# Altium schematic sheet 16MV1.SchDoc
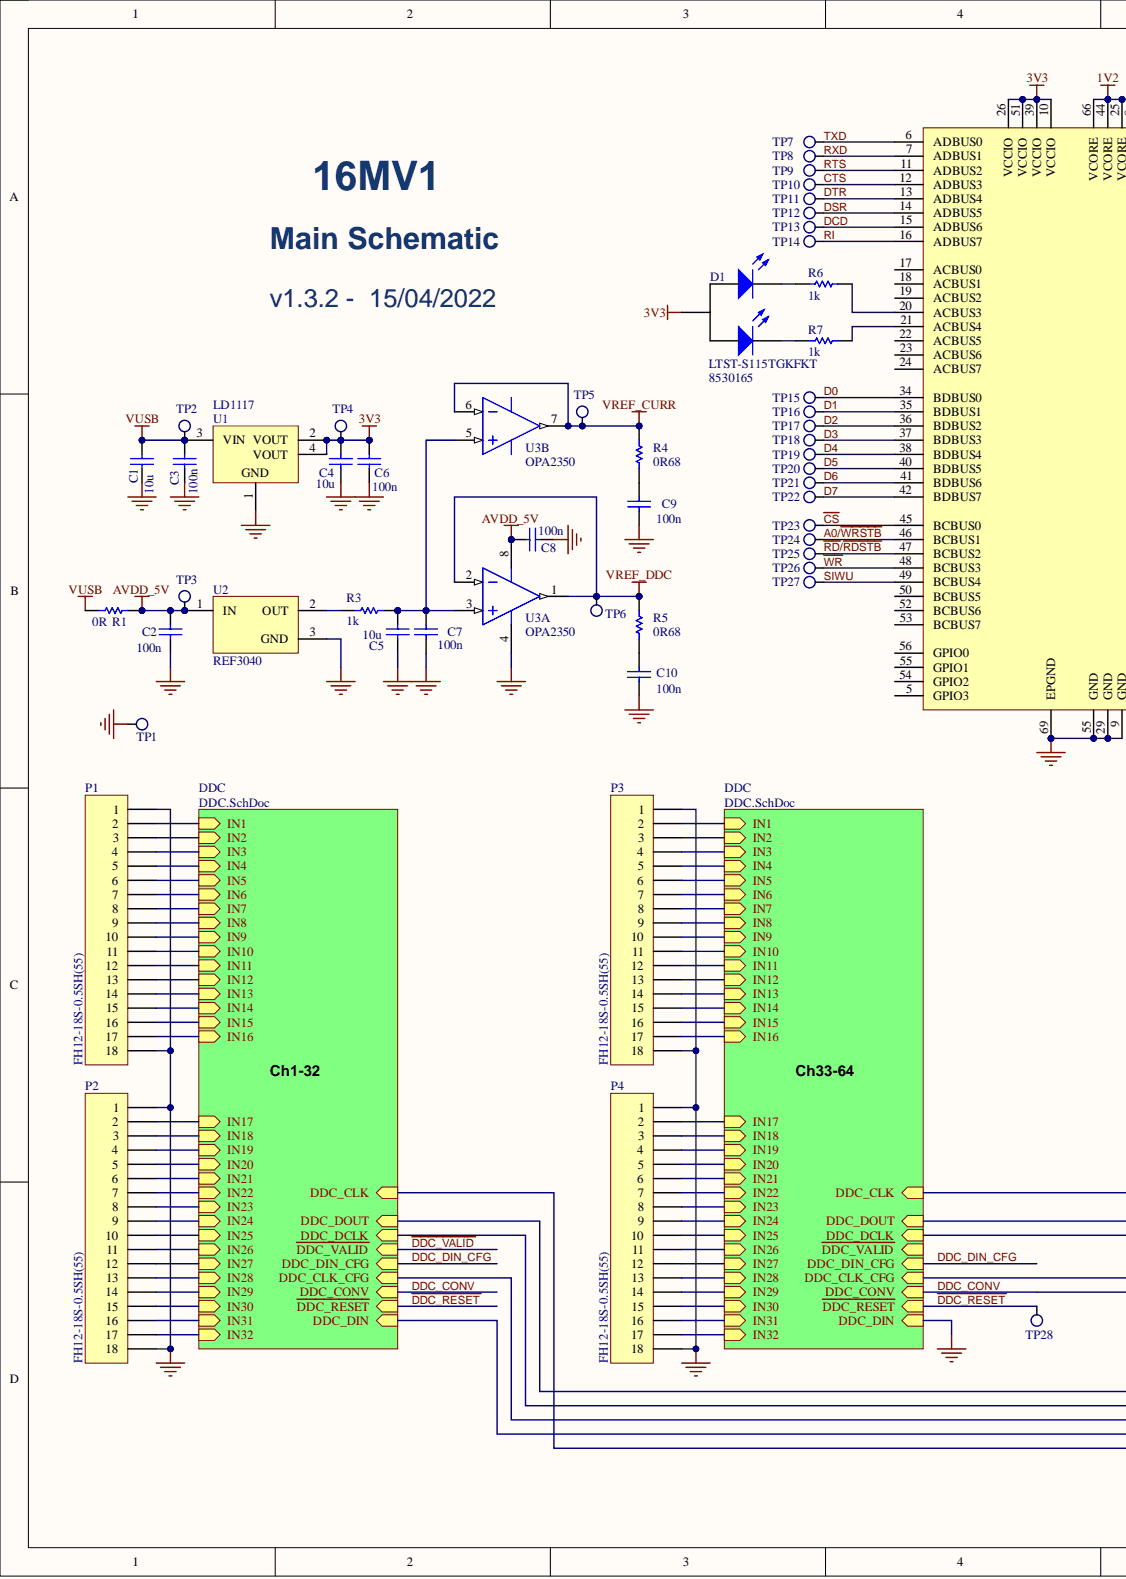
[diagram p0: 16MV1.SchDoc, left]
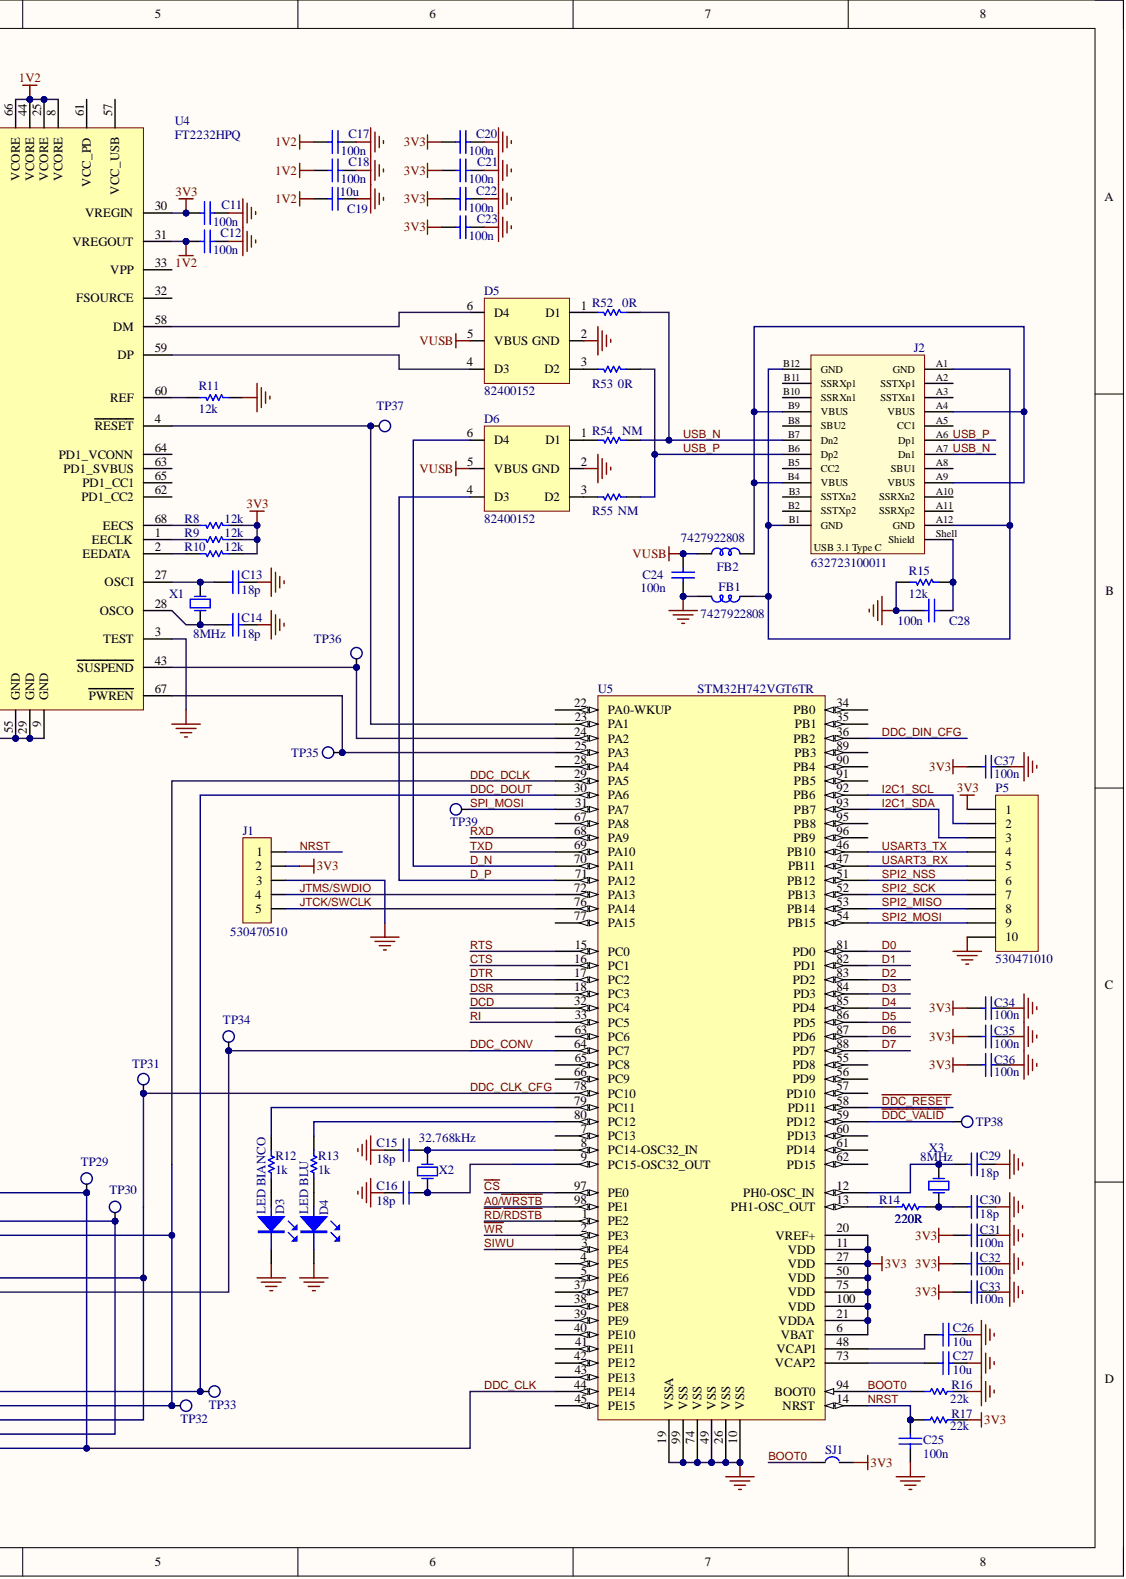
[diagram p1: 16MV1.SchDoc, right]

## Parts
C1  10u  [0603]
C2  100n  [0402]
C3  100n  [0402]
C4  10u  [0603]
C5  10u  [0603]
C6  100n  [0402]
C7  100n  [0402]
C8  100n  [0402]
C9  100n  [0402]
C10  100n  [0402]
C11  100n  [0402]
C12  100n  [0402]
C13  18p  [0402]
C14  18p  [0402]
C15  18p  [0402]
C16  18p  [0402]
C17  100n  [0402]
C18  100n  [0402]
C19  10u  [0603]
C20  100n  [0402]
C21  100n  [0402]
C22  100n  [0402]
C23  100n  [0402]
C24  100n  [0402]
C25  100n  [0402]
C26  10u  [0603]
C27  10u  [0603]
C28  100n  [0402]
C29  18p  [0402]
C30  18p  [0402]
C31  100n  [0402]
C32  100n  [0402]
C33  100n  [0402]
C34  100n  [0402]
C35  100n  [0402]
C36  100n  [0402]
C37  100n  [0402]
D1  LTST-S115TGKFKT  Supplier Part Number 1: 8530165  [LED BICOLOR R/A SMD]
D3  LED BIANCO  [LED_SMD]
D4  LED BLU  [LED_SMD]
D5  82400152  [SOT-666]
D6  82400152  [SOT-666]
FB1  7427922808  [0603]
FB2  7427922808  [0603]
J1  530470510  [MOLEX_5_V]
J2  632723100011  Interface Type: Type C  Sub-Family: USB 3.1  [632723100011]
P1  FH12-18S-0.5SH(55)  [FH12-18S-0.5SH(55)]
P2  FH12-18S-0.5SH(55)  [FH12-18S-0.5SH(55)]
P3  FH12-18S-0.5SH(55)  [FH12-18S-0.5SH(55)]
P4  FH12-18S-0.5SH(55)  [FH12-18S-0.5SH(55)]
P5  530471010  [HDR1X10]
R1  0R  [0402]
R3  1k  [0402]
R4  0R68  [0402]
R5  0R68  [0402]
R6  1k  [0402]
R7  1k  [0402]
R8  12k  [0402]
R9  12k  [0402]
R10  12k  [0402]
R11  12k  [0402]
R12  1k  [0402]
R13  1k  [0402]
R14  220R  [0402]
R15  12k  [0402]
R16  22k  [0402]
R17  22k  [0402]
R52  0R  [0402]
R53  0R  [0402]
R54  NM  [0402]
R55  NM  [0402]
SJ1  SJ  [Solder_j]
TP1  TestPoint  [PIAZZOLA]  (value not drawn)
TP2  TestPoint  [PIAZZOLA]  (value not drawn)
TP3  TestPoint  [PIAZZOLA]  (value not drawn)
TP4  TestPoint  [PIAZZOLA]  (value not drawn)
TP5  TestPoint  [PIAZZOLA]  (value not drawn)
TP6  TestPoint  [PIAZZOLA]  (value not drawn)
TP7  TestPoint  [PIAZZOLA]  (value not drawn)
TP8  TestPoint  [PIAZZOLA]  (value not drawn)
TP9  TestPoint  [PIAZZOLA]  (value not drawn)
TP10  TestPoint  [PIAZZOLA]  (value not drawn)
TP11  TestPoint  [PIAZZOLA]  (value not drawn)
TP12  TestPoint  [PIAZZOLA]  (value not drawn)
TP13  TestPoint  [PIAZZOLA]  (value not drawn)
TP14  TestPoint  [PIAZZOLA]  (value not drawn)
TP15  TestPoint  [PIAZZOLA]  (value not drawn)
TP16  TestPoint  [PIAZZOLA]  (value not drawn)
TP17  TestPoint  [PIAZZOLA]  (value not drawn)
TP18  TestPoint  [PIAZZOLA]  (value not drawn)
TP19  TestPoint  [PIAZZOLA]  (value not drawn)
TP20  TestPoint  [PIAZZOLA]  (value not drawn)
TP21  TestPoint  [PIAZZOLA]  (value not drawn)
TP22  TestPoint  [PIAZZOLA]  (value not drawn)
TP23  TestPoint  [PIAZZOLA]  (value not drawn)
TP24  TestPoint  [PIAZZOLA]  (value not drawn)
TP25  TestPoint  [PIAZZOLA]  (value not drawn)
TP26  TestPoint  [PIAZZOLA]  (value not drawn)
TP27  TestPoint  [Piazzola]  (value not drawn)
TP28  TestPoint  [PIAZZOLA]  (value not drawn)
TP29  TestPoint  [PIAZZOLA]  (value not drawn)
TP30  TestPoint  [PIAZZOLA]  (value not drawn)
TP31  TestPoint  [PIAZZOLA]  (value not drawn)
TP32  TestPoint  [PIAZZOLA]  (value not drawn)
TP33  TestPoint  [PIAZZOLA]  (value not drawn)
TP34  TestPoint  [PIAZZOLA]  (value not drawn)
TP35  TestPoint  [PIAZZOLA]  (value not drawn)
TP36  TestPoint  [PIAZZOLA]  (value not drawn)
TP37  TestPoint  [PIAZZOLA]  (value not drawn)
TP38  TestPoint  [PIAZZOLA]  (value not drawn)
TP39  TestPoint  [PIAZZOLA]  (value not drawn)
U1  LD1117  [SOT223-4M]
U2  REF3040  [SOT23-3L]
U3  OPA2350  [MSOP8]  (parts A,B)
U4  FT2232HPQ  [QFN68]
U5  STM32H742VGT6TR  [QFP50P1600X1600X160-100N]
X1  8MHz  [XTAL_SMD3225]
X2  32.768kHz  [XTAL FC-13]
X3  8MHz  [XTAL_SMD3225]

## Nets
1V2: C12.1 C17.1 C18.1 C19.2 U4.8(VCORE) U4.25(VCORE) U4.31(VREGOUT) U4.44(VCORE) U4.66(VCORE)
3V3: C4.1 C6.1 C11.1 C20.1 C21.1 C22.1 C23.1 C31.1 C32.1 C33.1 C34.1 C35.1 C36.1 C37.1 D1.1 J1.2 P5.1 R8.2 R9.2 R10.2 R17.2 SJ1.1 TP4.1 U1.2(VOUT) U1.4(VOUT) U4.10(VCCIO) U4.26(VCCIO) U4.30(VREGIN) U4.39(VCCIO) U4.51(VCCIO) U5.6(VBAT) U5.11(VDD) U5.20(VREF+) U5.21(VDDA) U5.27(VDD) U5.50(VDD) U5.75(VDD) U5.100(VDD)
AVDD_5V: C2.1 C8.1 R1.1 TP3.1 U2.1 U3.8
GND: C1.2 C2.2 C3.2 C4.2 C5.2 C6.2 C7.2 C8.2 C9.2 C10.2 C11.2 C12.2 C13.1 C14.1 C15.2 C16.2 C17.2 C18.2 C19.1 C20.2 C21.2 C22.2 C23.2 C24.2 C25.2 C26.1 C27.1 C28.2 C29.1 C30.1 C31.2 C32.2 C33.2 C34.2 C35.2 C36.2 C37.2 D3.2 D4.2 D5.2(GND) D6.2(GND) FB1.2 J1.3 P1.1 P1.18 P2.1 P2.18 P3.1 P3.18 P4.1 P4.18 P5.10 R11.2 R15.1 R16.2 TP1.1 U1.1 U2.3 U3.4 U4.3(TEST) U4.9(GND) U4.29(GND) U4.55 U4.69(EPGND) U5.10(VSS) U5.19(VSSA) U5.26(VSS) U5.49(VSS) U5.74(VSS) U5.99(VSS)
VREF_CURR: R4.2 TP5.1 U3.6 U3.7
VREF_DDC: R5.2 TP6.1 U3.1 U3.2
VUSB: C1.1 C3.1 C24.1 D5.5(VBUS) D6.5(VBUS) FB2.1 R1.2 TP2.1 U1.3(VIN)
A0/~{WRSTB}: TP24.1 U4.46(BCBUS1) U5.98(PE1)
BOOT0: R16.1 SJ1.2 U5.94(BOOT0)
~{CS}: TP23.1 U4.45(BCBUS0) U5.97(PE0)
CTS: TP10.1 U4.12(ADBUS3) U5.16(PC1)
D0: TP15.1 U4.34(BDBUS0) U5.81(PD0)
D1: TP16.1 U4.35(BDBUS1) U5.82(PD1)
D2: TP17.1 U4.36(BDBUS2) U5.83(PD2)
D3: TP18.1 U4.37(BDBUS3) U5.84(PD3)
D4: TP19.1 U4.38(BDBUS4) U5.85(PD4)
D5: TP20.1 U4.40(BDBUS5) U5.86(PD5)
D6: TP21.1 U4.41(BDBUS6) U5.87(PD6)
D7: TP22.1 U4.42(BDBUS7) U5.88(PD7)
~{DDC_RESET}: TP28.1 U5.58(PD11)
~{DDC_VALID}: TP38.1 U5.59(PD12)
D_N: D6.6(D4) U5.70(PA11)
D_P: D6.4(D3) U5.71(PA12)
DCD: TP13.1 U4.15(ADBUS6) U5.32(PC4)
DDC_CLK: TP29.1 U5.44(PE14)
DDC_CLK_CFG: TP31.1 U5.78(PC10)
DDC_CONV: TP34.1 U5.64(PC7)
DDC_DCLK: TP32.1 U5.29(PA5)
DDC_DIN_CFG: U5.36(PB2)
DDC_DOUT: TP33.1 U5.30(PA6)
DSR: TP12.1 U4.14(ADBUS5) U5.18(PC3)
DTR: TP11.1 U4.13(ADBUS4) U5.17(PC2)
I2C1_SCL: P5.2 U5.92(PB6)
I2C1_SDA: P5.3 U5.93(PB7)
JTCK/SWCLK: J1.5 U5.76(PA14)
JTMS/SWDIO: J1.4 U5.72(PA13)
NRST: C25.1 J1.1 R17.1 U5.14(NRST)
~{RD}/~{RDSTB}: TP25.1 U4.47(BCBUS2) U5.1(PE2)
RI: TP14.1 U4.16(ADBUS7) U5.33(PC5)
RTS: TP9.1 U4.11(ADBUS2) U5.15(PC0)
RXD: TP8.1 U4.7(ADBUS1) U5.68(PA9)
SIWU: TP27.1 U4.49(BCBUS4) U5.3(PE4)
SPI2_MISO: P5.8 U5.53(PB14)
SPI2_MOSI: P5.9 U5.54(PB15)
SPI2_NSS: P5.6 U5.51(PB12)
SPI2_SCK: P5.7 U5.52(PB13)
SPI_MOSI: TP39.1 U5.31(PA7)
TXD: TP7.1 U4.6(ADBUS0) U5.69(PA10)
USART3_RX: P5.5 U5.47(PB11)
USART3_TX: P5.4 U5.46(PB10)
USB_N: J2.A7(Dn1) J2.B7(Dn2) R52.2 R54.2
USB_P: J2.A6(Dp1) J2.B6(Dp2) R53.2 R55.2
~{WR}: TP26.1 U4.48(BCBUS3) U5.2(PE3)
NetC5_1: C5.1 C7.1 R3.1 U3.3 U3.5
NetC9_1: C9.1 R4.1
NetC10_1: C10.1 R5.1
NetC13_2: C13.2 U4.27(OSCI) X1.3
NetC14_2: C14.2 U4.28(OSCO) X1.1
NetC15_1: C15.1 U5.8(PC14-OSC32_IN) X2.2
NetC16_1: C16.1 U5.9(PC15-OSC32_OUT) X2.1
NetC26_2: C26.2 U5.48(VCAP1)
NetC27_2: C27.2 U5.73(VCAP2)
NetC28_1: C28.1 J2.Shell(Shield) R15.2
NetC29_2: C29.2 U5.12(PH0-OSC_IN) X3.3
NetC30_2: C30.2 R14.2 X3.1
NetD1_2: D1.2 R7.1
NetD1_3: D1.3 R6.1
NetD3_1: D3.1 R12.1
NetD4_1: D4.1 R13.1
NetD5_1: D5.1(D1) R52.1
NetD5_3: D5.3(D2) R53.1
NetD5_4: D5.4(D3) U4.59(DP)
NetD5_6: D5.6(D4) U4.58(DM)
NetD6_1: D6.1(D1) R54.1
NetD6_3: D6.3(D2) R55.1
NetFB1_1: FB1.1 J2.A1(GND) J2.A12(GND) J2.B1(GND) J2.B12(GND)
NetFB2_2: FB2.2 J2.A4(VBUS) J2.A9(VBUS) J2.B4(VBUS) J2.B9(VBUS)
NetJ2_A2: J2.A2(SSTXp1)
NetJ2_A3: J2.A3(SSTXn1)
NetJ2_A5: J2.A5(CC1)
NetJ2_A8: J2.A8(SBU1)
NetJ2_A10: J2.A10(SSRXn2)
NetJ2_A11: J2.A11(SSRXp2)
NetJ2_B2: J2.B2(SSTXp2)
NetJ2_B3: J2.B3(SSTXn2)
NetJ2_B5: J2.B5(CC2)
NetJ2_B8: J2.B8(SBU2)
NetJ2_B10: J2.B10(SSRXn1)
NetJ2_B11: J2.B11(SSRXp1)
NetP1_2: P1.2
NetP1_3: P1.3
NetP1_4: P1.4
NetP1_5: P1.5
NetP1_6: P1.6
NetP1_7: P1.7
NetP1_8: P1.8
NetP1_9: P1.9
NetP1_10: P1.10
NetP1_11: P1.11
NetP1_12: P1.12
NetP1_13: P1.13
NetP1_14: P1.14
NetP1_15: P1.15
NetP1_16: P1.16
NetP1_17: P1.17
NetP2_2: P2.2
NetP2_3: P2.3
NetP2_4: P2.4
NetP2_5: P2.5
NetP2_6: P2.6
NetP2_7: P2.7
NetP2_8: P2.8
NetP2_9: P2.9
NetP2_10: P2.10
NetP2_11: P2.11
NetP2_12: P2.12
NetP2_13: P2.13
NetP2_14: P2.14
NetP2_15: P2.15
NetP2_16: P2.16
NetP2_17: P2.17
NetP3_2: P3.2
NetP3_3: P3.3
NetP3_4: P3.4
NetP3_5: P3.5
NetP3_6: P3.6
NetP3_7: P3.7
NetP3_8: P3.8
NetP3_9: P3.9
NetP3_10: P3.10
NetP3_11: P3.11
NetP3_12: P3.12
NetP3_13: P3.13
NetP3_14: P3.14
NetP3_15: P3.15
NetP3_16: P3.16
NetP3_17: P3.17
NetP4_2: P4.2
NetP4_3: P4.3
NetP4_4: P4.4
NetP4_5: P4.5
NetP4_6: P4.6
NetP4_7: P4.7
NetP4_8: P4.8
NetP4_9: P4.9
NetP4_10: P4.10
NetP4_11: P4.11
NetP4_12: P4.12
NetP4_13: P4.13
NetP4_14: P4.14
NetP4_15: P4.15
NetP4_16: P4.16
NetP4_17: P4.17
NetR3_2: R3.2 U2.2
NetR6_2: R6.2 U4.20(ACBUS3)
NetR7_2: R7.2 U4.21(ACBUS4)
NetR8_1: R8.1 U4.68(EECS)
NetR9_1: R9.1 U4.1(EECLK)
NetR10_1: R10.1 U4.2(EEDATA)
NetR11_1: R11.1 U4.60(REF)
NetR12_2: R12.2 U5.79(PC11)
NetR13_2: R13.2 U5.80(PC12)
NetR14_1: R14.1 U5.13(PH1-OSC_OUT)
NetTP30_1: TP30.1
NetTP35_1: TP35.1 U4.67(PWREN) U5.25(PA3)
NetTP36_1: TP36.1 U4.43(SUSPEND) U5.24(PA2)
NetTP37_1: TP37.1 U4.4(RESET) U5.23(PA1)
NetU4_5: U4.5(GPIO3)
NetU4_17: U4.17(ACBUS0)
NetU4_18: U4.18(ACBUS1)
NetU4_19: U4.19(ACBUS2)
NetU4_22: U4.22(ACBUS5)
NetU4_23: U4.23(ACBUS6)
NetU4_24: U4.24(ACBUS7)
NetU4_32: U4.32(FSOURCE)
NetU4_33: U4.33(VPP)
NetU4_50: U4.50(BCBUS5)
NetU4_52: U4.52(BCBUS6)
NetU4_53: U4.53(BCBUS7)
NetU4_54: U4.54(GPIO2)
NetU4_55: U4.55
NetU4_56: U4.56(GPIO0)
NetU4_57: U4.57(VCC_USB)
NetU4_61: U4.61(VCC_PD)
NetU4_62: U4.62(PD1_CC2)
NetU4_63: U4.63(PD1_SVBUS)
NetU4_64: U4.64(PD1_VCONN)
NetU4_65: U4.65(PD1_CC1)
NetU5_4: U5.4(PE5)
NetU5_5: U5.5(PE6)
NetU5_7: U5.7(PC13)
NetU5_22: U5.22(PA0-WKUP)
NetU5_28: U5.28(PA4)
NetU5_34: U5.34(PB0)
NetU5_35: U5.35(PB1)
NetU5_37: U5.37(PE7)
NetU5_38: U5.38(PE8)
NetU5_39: U5.39(PE9)
NetU5_40: U5.40(PE10)
NetU5_41: U5.41(PE11)
NetU5_42: U5.42(PE12)
NetU5_43: U5.43(PE13)
NetU5_45: U5.45(PE15)
NetU5_55: U5.55(PD8)
NetU5_56: U5.56(PD9)
NetU5_57: U5.57(PD10)
NetU5_60: U5.60(PD13)
NetU5_61: U5.61(PD14)
NetU5_62: U5.62(PD15)
NetU5_63: U5.63(PC6)
NetU5_65: U5.65(PC8)
NetU5_66: U5.66(PC9)
NetU5_67: U5.67(PA8)
NetU5_77: U5.77(PA15)
NetU5_89: U5.89(PB3)
NetU5_90: U5.90(PB4)
NetU5_91: U5.91(PB5)
NetU5_95: U5.95(PB8)
NetU5_96: U5.96(PB9)

## Library data of the parts
C1 C4 C5 C19 C26 C27 = Cap 10uF: Capacitor X5R 6.3V 10%; Component Kind: Standard; LatestRevisionDate: 17-Jul-2002; LatestRevisionNote: Re-released for DXP Platform.; Library Name: 16MV1.SCHLIB; Published: 8-Jun-2000; Signal Integrity: Cap; Simulation: CAP; Publisher: Altium Limited
C2 C3 C6-C12 C17 C18 C20-C25 C28 C31-C37 = Cap 100nF: Capacitor X7R 50V 10%; Component Kind: Standard; LatestRevisionDate: 17-Jul-2002; LatestRevisionNote: Re-released for DXP Platform.; Library Name: 16MV1.SCHLIB; Published: 8-Jun-2000; Signal Integrity: Cap; Simulation: CAP; Publisher: Altium Limited
  C31-C37: PackageDescription: Radial Cap, Thru-Hole; 2 Leads; 0.3 in Pin Spacing; PackageReference: RAD-0.3; Value: 100n
C13-C16 C29 C30 = Cap 18pF: Capacitor COG 50V 10%; Component Kind: Standard; LatestRevisionDate: 17-Jul-2002; LatestRevisionNote: Re-released for DXP Platform.; Library Name: 16MV1.SCHLIB; PackageDescription: Radial Cap, Thru-Hole; 2 Leads; 0.3 in Pin Spacing; PackageReference: RAD-0.3; Published: 8-Jun-2000; Signal Integrity: Cap; Simulation: CAP; Value: 18p; Publisher: Altium Limited
D1 = Led Bicolor 4: Led bicolor Right angle; Component Kind: Standard; Library Name: 16MV1.SCHLIB; Supplier 1: Farnell
D3 = LED BIANCO: LED, QuasarBrite, bianco, SMD, 2mm x 1,6mm, 20 mA, 3.5 V, 90 mcd; Component Kind: Standard; Library Name: 16MV1.SCHLIB; Supplier 1: Digi-Key; Supplier 2: Farnell; Supplier Part Number 1: 67-1866-1-ND; Supplier Part Number 2: 2062228
D4 = LED BLU: LED, BLUE, 3.2MM X 1.6MM, 25MCD, 470NM; Component Kind: Standard; Library Name: 16MV1.SCHLIB; Supplier 1: Digi-Key; Supplier 2: Farnell; Supplier Part Number 1: 67-1701-1-ND; Supplier Part Number 2: 1020463
D5 D6 = 82400152: WE-TVS TVS Diode – High speed Series; Component Kind: Standard; Library Name: 16MV1.SCHLIB; Supplier 1: Digi-Key; Supplier 2: Farnell; Supplier Part Number 1: 732-4474-1-ND; Supplier Part Number 2: 1825874
FB1 FB2 = 7427922808: Ferrite bead; Component Kind: Standard; Library Name: 16MV1.SCHLIB; Supplier 1: Digi-Key; Supplier 2: Farnell; Supplier Part Number 1: 732-6114-1-ND; Supplier Part Number 2: 2467183
J1 = Header 5: Header, 5-Pin; Component Kind: Standard; Library Name: 16MV1.SCHLIB; Supplier 1: Digi-Key; Supplier 2: Farnell; Supplier Part Number 1: WM1734-ND; Supplier Part Number 2: 9732861
J2 = 632723100011: USB 3.1 Type C Receptacle Horizontal THR WR-COM; Component Kind: Standard; ComponentLink1Description: Manufacturer; ComponentLink1URL: http://www.we-online.de; ComponentLink2Description: Datasheet; ComponentLink2URL: http://katalog.we-online.de/em/datasheet/632723x00011.pdf; Construction: THR; Gender: Receptacle; Library Name: 16MV1.SCHLIB; Part Number: 632723100011; Published Date: April 2017; Supplier 1: Digi-Key; Supplier 2: Farnell; Supplier Part Number 1: 732-9617-1-ND; Supplier Part Number 2: 2984360; Type: Horizontal; Publisher: Wurth Elektronik
P1-P4 = FH12-18S-0.5SH(55): Header, 18-Pin; Component Kind: Standard; LatestRevisionDate: 17-Jul-2002; LatestRevisionNote: Re-released for DXP Platform.; Library Name: 16MV1.SCHLIB; Signal Integrity: Connector; Supplier 1: Digi-Key; Supplier 2: RSComponents; Supplier Part Number 1: HFJ118CT-ND; Supplier Part Number 2: 7388824; Publisher: Altium Limited
P5 = Header 10: Header, 10-Pin; Component Kind: Standard; LatestRevisionDate: 17-Jul-2002; LatestRevisionNote: Re-released for DXP Platform.; Library Name: 16MV1.SCHLIB; Signal Integrity: Connector; Publisher: Altium Limited
R1 R52 R53 = Res 0R: Resistor 0.1W 1%; Component Kind: Standard; LatestRevisionDate: 17-Jul-2002; LatestRevisionNote: Re-released for DXP Platform.; Library Name: 16MV1.SCHLIB; PackageDescription: Axial Device, Thru-Hole; 2 Leads; 0.3 in Pin Spacing; PackageReference: AXIAL-0.3; Published: 8-Jun-2000; Signal Integrity: Res; Simulation: RESISTOR; Value: 0R; Publisher: Altium Limited
R3 R6 R7 R12 R13 = Res 1k: Resistor 0.1W 1%; Component Kind: Standard; Library Name: 16MV1.SCHLIB; Published: 8-Jun-2000; Signal Integrity: Res; Simulation: RESISTOR; Publisher: Altium Limited
  R3: LatestRevisionDate: 17-Jul-2002; LatestRevisionNote: Re-released for DXP Platform.; PackageDescription: Axial Device, Thru-Hole; 2 Leads; 0.3 in Pin Spacing; PackageReference: AXIAL-0.3; Value: 1k
  R6 R7 R12 R13: Revision: July-2002: Re-released for DXP Platform.
R4 R5 = Res 0R68: Resistor 0.1W 1%; Component Kind: Standard; LatestRevisionDate: 17-Jul-2002; LatestRevisionNote: Re-released for DXP Platform.; Library Name: 16MV1.SCHLIB; PackageDescription: Axial Device, Thru-Hole; 2 Leads; 0.3 in Pin Spacing; PackageReference: AXIAL-0.3; Published: 8-Jun-2000; Signal Integrity: Res; Simulation: RESISTOR; Value: 0R68; Publisher: Altium Limited
R8-R11 R15 = Res 12k: Resistor 0.1W 1%; Value: 10k; Component Kind: Standard; LatestRevisionDate: 17-Jul-2002; LatestRevisionNote: Re-released for DXP Platform.; Library Name: 16MV1.SCHLIB; PackageDescription: Axial Device, Thru-Hole; 2 Leads; 0.3 in Pin Spacing; PackageReference: AXIAL-0.3; Published: 8-Jun-2000; Signal Integrity: Res; Simulation: RESISTOR; Publisher: Altium Limited
R14 = Res 220R: Resistor 0.1W 1%; Component Kind: Standard; LatestRevisionDate: 17-Jul-2002; LatestRevisionNote: Re-released for DXP Platform.; Library Name: 16MV1.SCHLIB; PackageDescription: Axial Device, Thru-Hole; 2 Leads; 0.3 in Pin Spacing; PackageReference: AXIAL-0.3; Published: 8-Jun-2000; Signal Integrity: Res; Simulation: RESISTOR; Value: 220R; Publisher: Altium Limited
R16 R17 = Res 22k: Resistor 0.1W 1%; Component Kind: Standard; LatestRevisionDate: 17-Jul-2002; LatestRevisionNote: Re-released for DXP Platform.; Library Name: 16MV1.SCHLIB; Published: 8-Jun-2000; Signal Integrity: Res; Simulation: RESISTOR; Publisher: Altium Limited
R54 R55 = Res NM: Resistor 0.1W 1%; Fitted: NO; Component Kind: Standard; Library Name: 16MV1.SCHLIB; Published: 8-Jun-2000; Revision: July-2002: Re-released for DXP Platform.; Signal Integrity: Res; Simulation: RESISTOR; Value: NM; Publisher: Altium Limited
SJ1 = SJ: Solder Jumper; Component Kind: Standard; Library Name: 16MV1.SCHLIB
U1 = LD1117: Fixed Low Drop Positive Voltage Regulator, 3.3V, 4-Pin SOT-223, Tape and Reel; Manufacturer: STMicroelectronics; Manufacturer Part Number: LD1117S33CTR; Component Kind: Standard; ComponentLink1Description: Manufacturer URL; ComponentLink1URL: http://www.st.com; ComponentLink2Description: Datasheet; ComponentLink2URL: http://www.st.com/internet/com/TECHNICAL_RESOURCES/TECHNICAL_LITERATURE/DATASHEET/CD00000544.pdf; DatasheetVersion: 1/11/2011; Library Name: 16MV1.SCHLIB; PackageDescription: 4-Pin SOT-223 Package, 6.5 x 3.5 mm Body, 2.3 mm Pitch, 7 mm Lead Span; PackageReference: SOT-223; PackageVersion: 2010; Supplier 1: Digi-Key; Supplier 2: Farnell; Supplier Part Number 1: 497-1241-1-ND; Supplier Part Number 2: 1467779
U2 = REF3040: 4.096 V, 50 ppm / degC, 50 uA Series (Bandgap) Voltage Reference, -40 to 125 degC, 3-pin SOT-23 (DBZ), Green (RoHS & no Sb/Br); Manufacturer: Texas Instruments; Manufacturer Part Number: REF3040AIDBZT; Initial Accuracy @ 25/deg C(%): 0.2; Iq(Max)(uA): 50; Rating: Catalog; SubFamily: Series Voltage Reference; Temp Coeff(Typ)(ppm/ degree C): 20; VI(Max)(V): 5.5; VI(Min)(V): 4.097; VO(V): 4.096; Code_JEDEC: TO-236; Component Kind: Standard; ComponentLink1Description: Manufacturer URL; ComponentLink1URL: http://www.ti.com/; ComponentLink2Description: Datasheet; ComponentLink2URL: http://www.ti.com/general/docs/lit/getliterature.tsp?genericPartNumber=REF3040&fileType=pdf; ComponentLink3Description: Package Specification; ComponentLink3URL: http://www.ti.com/litv/pdf/mpds108a; DatasheetVersion: SBVS032F; Eco-Plan: Green (RoHS & no Sb/Br); Library Name: 16MV1.SCHLIB; Mounting Technology: Surface Mount; PackageDescription: 3-Pin Plastic Small Outline, Body 2.92 x 1.3 mm, Pitch 0.95 mm; PackageReference: DBZ0003A; PackageVersion: 4203227/B; PartNumber: REF3040AIDBZT; Supplier 1: Digi-Key; Supplier 2: Farnell; Supplier Part Number 1: REF3040AIDBZTCT-ND; Supplier Part Number 2: 3124532; Temp Coeff(Max)(ppm/ degree C): 50
U3 = OPA2350: High-Speed, Single-Supply, Rail-to-Rail Operational Amplifier MicroAmplifier(TM) Series, 2.7 to 5.5 V, -40 to 85 degC, 8-pin SOP (DGK8), Green (RoHS & no Sb/Br); Manufacturer: Texas Instruments; Manufacturer Part Number: OPA2350EA/250; CMRR(Min)(dB): 74; IIB(Max)(pA): 10; Iq per channel(Max)(mA): 8.5; Number of Channels: 2; Offset Drift(Typ)(uV/C): 4; Slew Rate(Typ)(V/us): 22; Sub Family: Low Input Bias Current Amp (<=10pA), Low Offset Voltage Amplifier (<=500uV), Low Supply Voltage Amplifier (<=2.7V), Precision Amplifier; Total Supply Voltage(Max)(+5V is 5, +/-5V is 10): 5.5; Total Supply Voltage(Min)(+5V is 5, +/-5V is 10): 2.7; Vio (25C)(Max)(mV): 1; Vn at 1kHz(Typ)(nV/rtHz): 18; Code_JEDEC: MO-187; Component Kind: Standard; ComponentLink1Description: Manufacturer URL; ComponentLink1URL: http://www.ti.com/; ComponentLink2Description: Datasheet; ComponentLink2URL: http://www.ti.com/general/docs/lit/getliterature.tsp?genericPartNumber=OPA2350&fileType=pdf; ComponentLink3Description: Package Specification; ComponentLink3URL: http://www.ti.com/litv/pdf/mpds028d; DatasheetVersion: SBOS099C; Eco-Plan: Green (RoHS & no Sb/Br); Library Name: 16MV1.SCHLIB; Mounting Technology: Surface Mount; PackageDescription: 8-Pin Thin Small Outline, Body 3 x 3 mm, Pitch 0.65 mm; PackageReference: DGK0008A; PackageVersion: 4073329/E, 05/2006; PartNumber: OPA2350EA/250; Supplier 1: Digi-Key; Supplier 2: Farnell; Supplier Part Number 1: OPA2350EACT-ND; Supplier Part Number 2: 3117460
U4 = FT2232HPQ: High Speed USB Bridge with Type-C/PD3.0  Controller; Component Kind: Standard; Library Name: 16MV1.SCHLIB; Supplier 1: Mouser; Supplier 2: Digi-Key; Supplier Part Number 1: 895-FT2232HPQ-REEL; Supplier Part Number 2: 768-FT2232HPQ-REELTR-ND
U5 = STM32F742VGT6: High-performance and DSP with DP-FPU, Arm Cortex-M7 MCU with 1MBytes of Flash memory, 692KB RAM, 480 MHz CPU, L1 cache, external memory interface, subset of peripherals; Component Kind: Standard; Library Name: 16MV1.SCHLIB; Supplier 1: Mouser; Supplier 2: Digi-Key; Supplier Part Number 1: 511-STM32H742VGT6TR; Supplier Part Number 2: 497-STM32H742VGT6TR-ND
X1 X3 = XTAL_8MHz: Crystal Oscillator; Component Kind: Standard; LatestRevisionDate: 17-Jul-2002; LatestRevisionNote: Re-released for DXP Platform.; Library Name: 16MV1.SCHLIB; PackageDescription: Crystal, Thru-Hole; 2 Leads; Body 3.1 x 8.2 mm (Dia.xH); PackageReference: R38; Published: 8-Jun-2000; Simulation: XTAL; Supplier 1: Digi-Key; Supplier 2: Mouser; Supplier Part Number 1: 535-9720-1-ND; Supplier Part Number 2: 815-ABM3B-8.0-B2-T; Publisher: Altium Limited
X2 = XTAL_32kHz: Crystal Oscillator; Component Kind: Standard; LatestRevisionDate: 17-Jul-2002; LatestRevisionNote: Re-released for DXP Platform.; Library Name: 16MV1.SCHLIB; PackageReference: R38; Published: 8-Jun-2000; Supplier 1: Digi-Key; Supplier 2: Mouser; Supplier Part Number 1: 535-9542-1-ND; Supplier Part Number 2: 815-ABS07-32.768KHZT; Publisher: Altium Limited
TP1-TP39 = TestPoint: Component Kind: Standard; Library Name: 16MV1.SCHLIB
